# Altium schematic sheet DC-DC.SchDoc
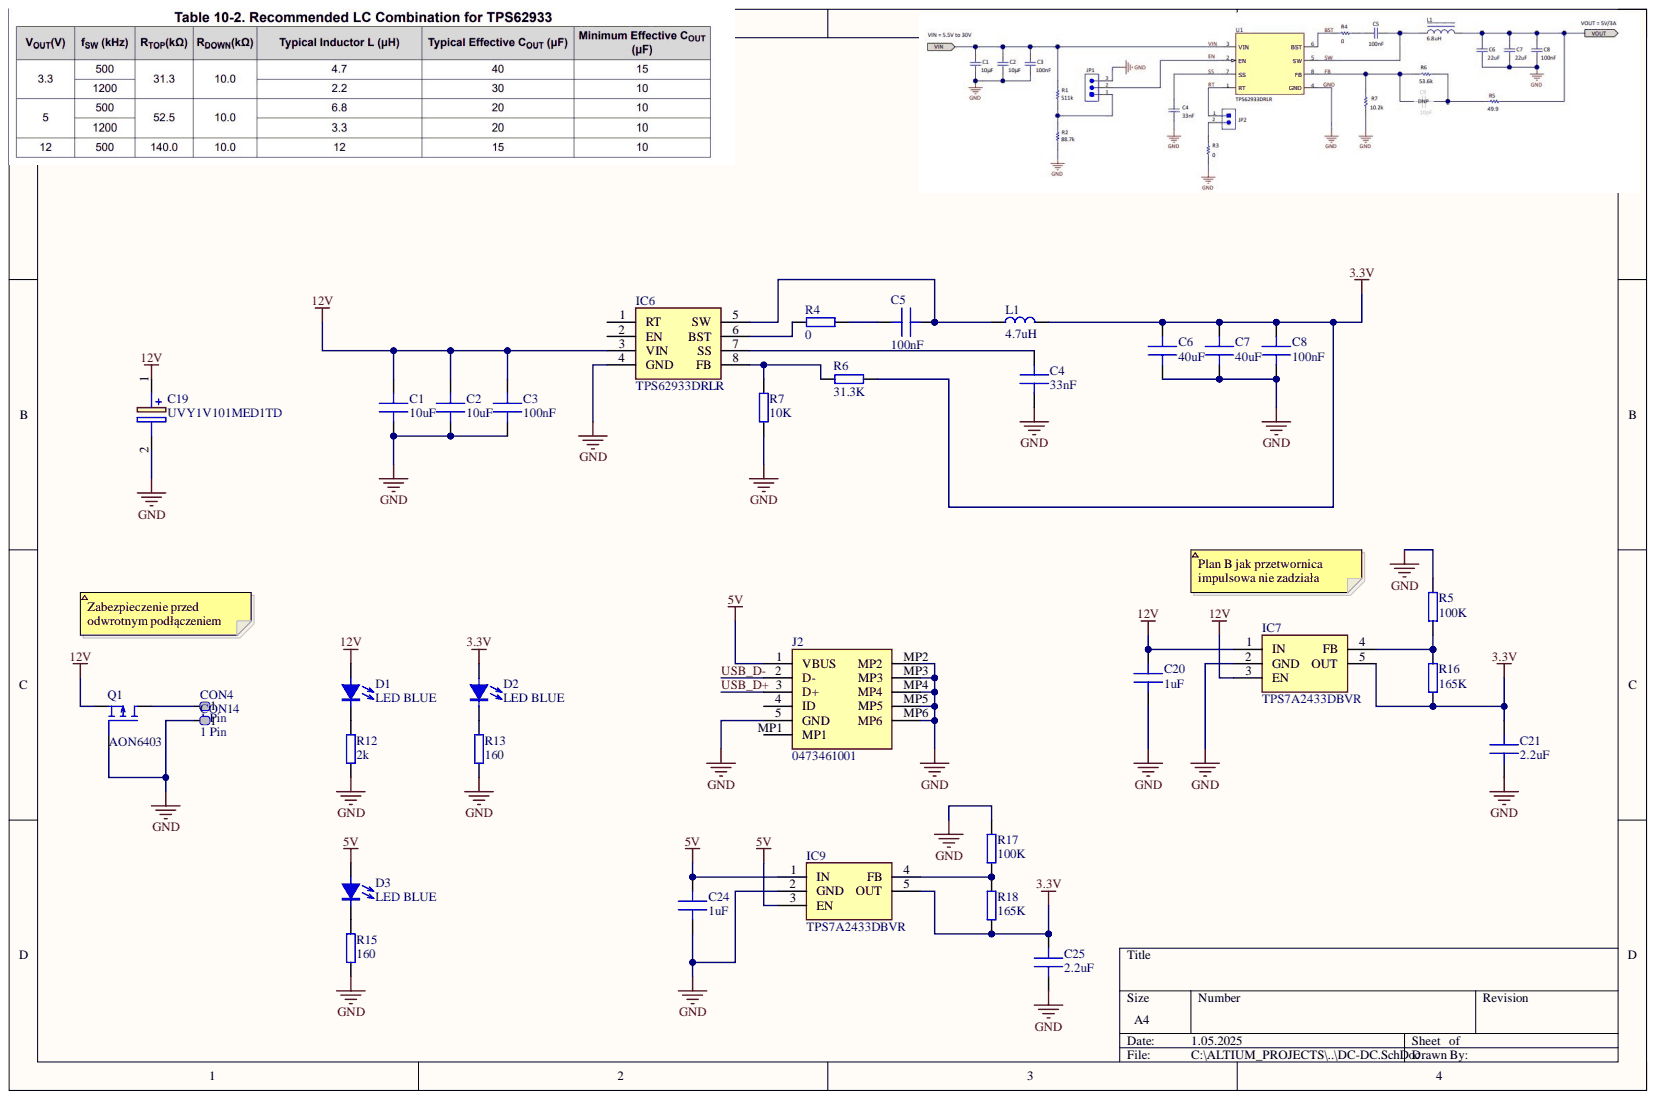
[diagram p0: DC-DC.SchDoc]

## Parts
C1  10uF  [C 1206]
C2  10uF  [C 1206]
C3  100nF  [C 0603]
C4  33nF  [C 0402]
C5  100nF  [C 0402]
C6  40uF  [C 1206]
C7  40uF  [C 1206]
C8  100nF  [C 0603]
C19  UVY1V101MED1TD  [CAPPRD250W50D655H1250]
C20  1uF  [C 0603]
C21  2.2uF  [C 0603]
C24  1uF  [C 0603]
C25  2.2uF  [C 0603]
CON4  1 Pin  [SMD PAD]
CON14  1 Pin  [SMD PAD]
D1  LED BLUE  [LED 0603 BLUE]
D2  LED BLUE  [LED 0603 BLUE]
D3  LED BLUE  [LED 0603 BLUE]
IC6  TPS62933DRLR  [SOTFL50P160X60-8N]
IC7  TPS7A2433DBVR  [SOT95P280X145-5N]
IC9  TPS7A2433DBVR  [SOT95P280X145-5N]
J2  0473461001  [0473461001]
L1  4.7uH  [HPI0630]
Q1  AON6403  [DFN 5x6]
R4  0  [R 0402]
R5  100K  [R 0402]
R6  31.3K  [R 0402]
R7  10K  [R 0402]
R12  2k  [R 0402]
R13  160  [R 0402]
R15  160  [R 0402]
R16  165K  [R 0402]
R17  100K  [R 0402]
R18  165K  [R 0402]

## Nets
3.3V: C6.1 C7.1 C8.1 C21.1 C25.1 D2.1 IC7.5(OUT) IC9.5(OUT) L1.2 R6.1 R16.2 R18.1
5V: C24.1 D3.1 IC9.1(IN) IC9.3(EN) J2.1(VBUS)
12V: C1.1 C2.1 C3.1 C19.1 C20.1 D1.1 IC6.3(VIN) IC7.1(IN) IC7.3(EN) Q1.1, 2, 3
GND: C1.2 C2.2 C3.2 C4.2 C6.2 C7.2 C8.2 C19.2 C20.2 C21.2 C24.2 C25.2 CON14.1 IC6.4(GND) IC7.2(GND) IC9.2(GND) J2.5(GND) J2.MP2 J2.MP3 J2.MP4 J2.MP5 J2.MP6 Q1.4 R5.1 R7.1 R12.1 R13.1 R15.1 R17.2
USB_D+: J2.3(D+)
USB_D-: J2.2(D-)
NetC4_1: C4.1 IC6.7(SS)
NetC5_1: C5.1 R4.1
NetC5_2: C5.2 IC6.5(SW) L1.1
NetCON4_1: CON4.1 Q1.5_8
NetD1_2: D1.2 R12.2
NetD2_2: D2.2 R13.2
NetD3_2: D3.2 R15.2
NetIC6_6: IC6.6(BST) R4.2
NetIC6_8: IC6.8(FB) R6.2 R7.2
NetIC7_4: IC7.4(FB) R5.2 R16.1
NetIC9_4: IC9.4(FB) R17.1 R18.2

Not connected: IC6 1(RT) 2(EN); J2 4(ID) MP1

## Library data of the parts
C1-C8 C20 C21 C24 C25 = Capacitor: MLCC Capacitor; Component Kind: Standard; Library Name: Components.IntLib
C19 = UVY1V101MED1TD: Capacitor Polarised; Manufacturer_Name: Nichicon; Arrow Part Number: UVY1V101MED1TD; Arrow Price/Stock: https://www.arrow.com/en/products/uvy1v101med1td/nichicon; Component Kind: Standard; Datasheet Link: http://nichicon-us.com/english/products/pdfs/e-uvy.pdf; Height: 12.5mm; Manufacturer_Part_Number: UVY1V101MED1TD
IC6 = TPS62933DRLR: Integrated Circuit; Manufacturer_Name: Texas Instruments; Arrow Part Number: TPS62933DRLR; Arrow Price/Stock: https://www.arrow.com/en/products/tps62933drlr/texas-instruments?utm_currency=USD&region=nac; Component Kind: Standard; Datasheet Link: https://www.ti.com/lit/ds/symlink/tps62933.pdf?ts=1626073394234; Height: 0.6mm; Manufacturer_Part_Number: TPS62933DRLR; Mouser Part Number: 595-TPS62933DRLR; Mouser Price/Stock: https://www.mouser.co.uk/ProductDetail/Texas-Instruments/TPS62933DRLR?qs=Rp5uXu7WBW9fv47wfQVntQ%3D%3D
IC7 IC9 = TPS7A2433DBVR: Integrated Circuit; Manufacturer_Name: Texas Instruments; Arrow Part Number: TPS7A2433DBVR; Arrow Price/Stock: null?region=nac; Component Kind: Standard; Datasheet Link: https://www.ti.com/lit/gpn/TPS7A24; Height: 1.45mm; Manufacturer_Part_Number: TPS7A2433DBVR; Mouser Part Number: 595-TPS7A2433DBVR; Mouser Price/Stock: https://www.mouser.co.uk/ProductDetail/Texas-Instruments/TPS7A2433DBVR?qs=wnTfsH77Xs7ROpwa%2Fm0jWA%3D%3D
J2 = 0473461001: Connector; Manufacturer_Name: Molex; Arrow Part Number: 0473461001; Arrow Price/Stock: https://www.arrow.com/en/products/0473461001/molex?region=nac; Component Kind: Standard; Datasheet Link: https://componentsearchengine.com/Datasheets/1/0473461001.pdf; Height: 2.45mm; Manufacturer_Part_Number: 0473461001; Mouser Part Number: N/A; Mouser Price/Stock: https://www.mouser.co.uk/ProductDetail/Molex/0473461001?qs=2bnkQPT%252BPafTZgGVt%2FOGfA%3D%3D
L1 = Inductor: General pourpouse inductor; Component Kind: Standard; Library Name: Components.IntLib
Q1 = AON6403: P-Mosfet 30V 67A Rds(on) 3.1mR; Component Kind: Standard; Library Name: Components.IntLib
R4-R7 R12 R13 R15-R18 = Resistor: General pourpouse resistor; Component Kind: Standard; Library Name: Components.IntLib
CON4 CON14 = 1 Pin: Component Kind: Standard; Library Name: Connectors.IntLib
D1-D3 = LED BLUE: Component Kind: Standard; Library Name: Components.IntLib
